# Altium schematic sheet Extra7_GPIO.SchDoc
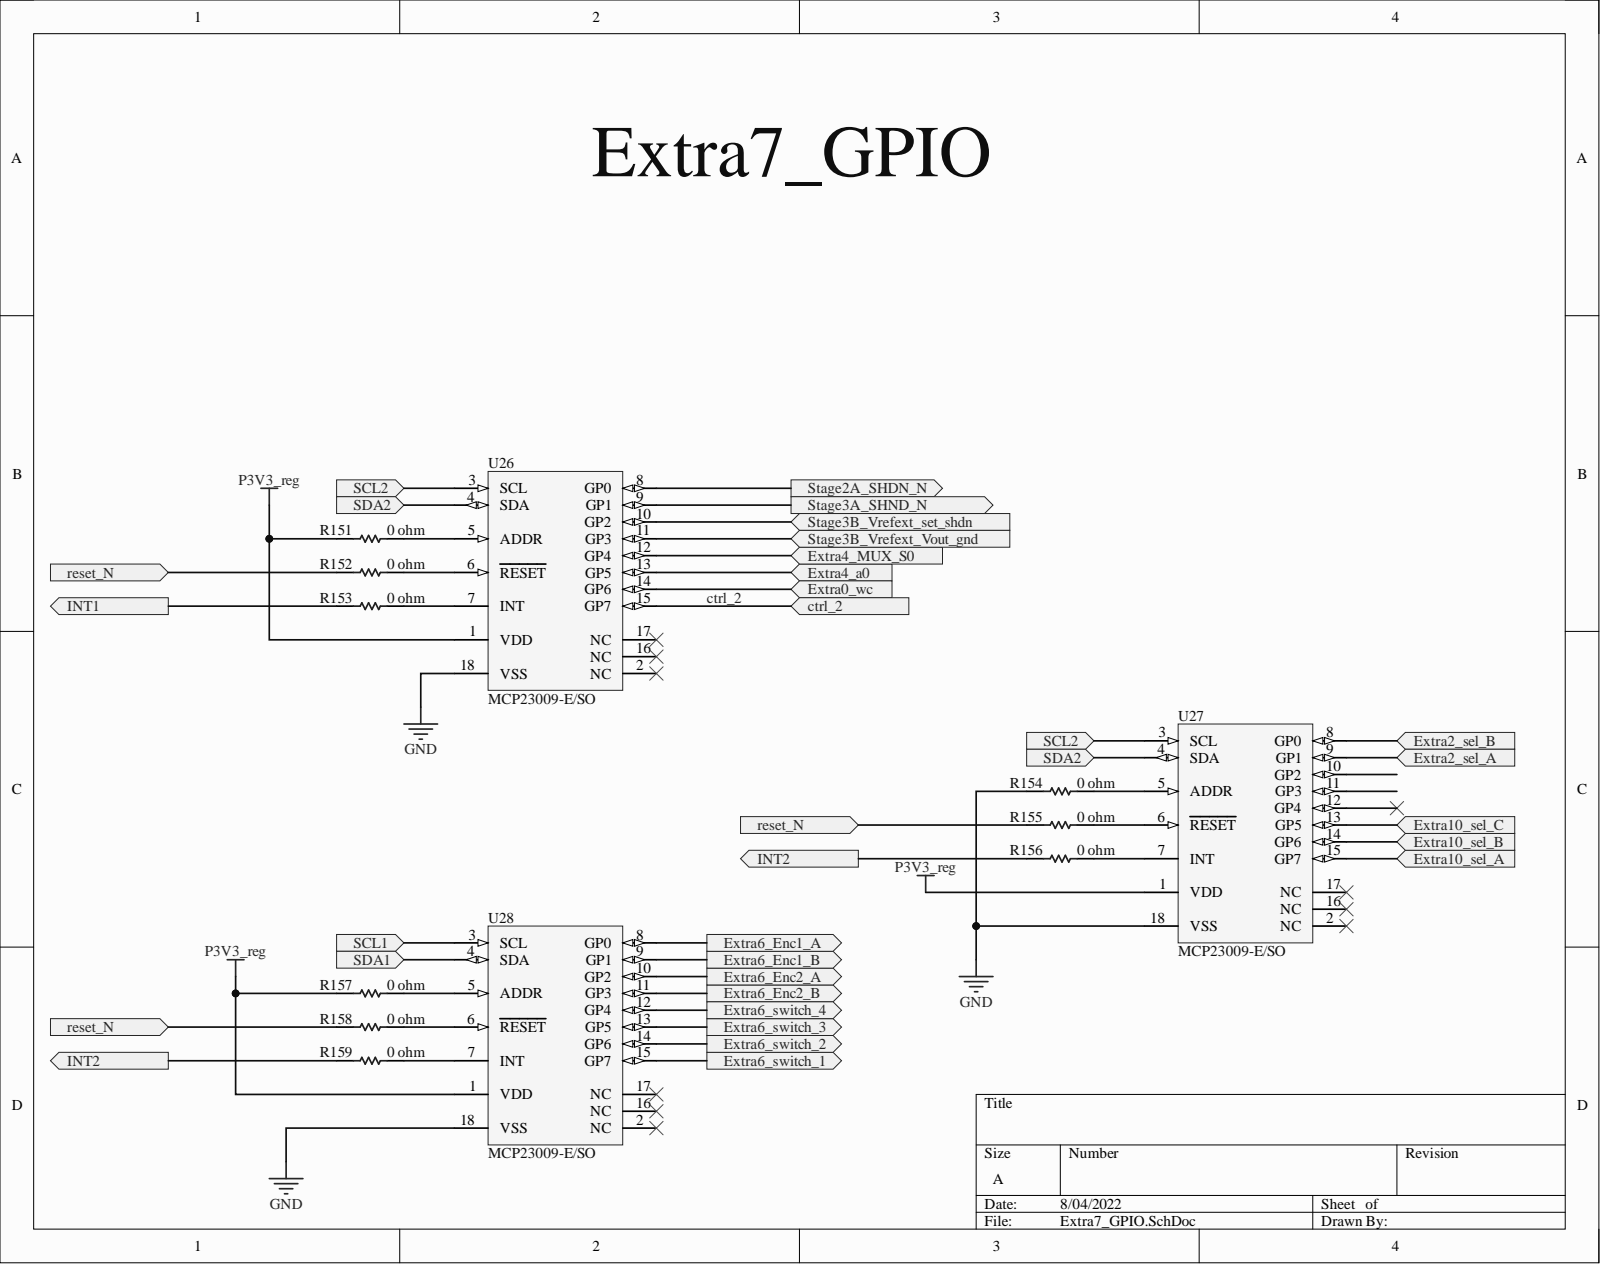
[diagram p0: Extra7_GPIO.SchDoc]

## Parts
R151  0 ohm  [RESC2013X50X30LL20T20]
R152  0 ohm  [RESC2013X50X30LL20T20]
R153  0 ohm  [RESC2013X50X30LL20T20]
R154  0 ohm  [RESC2013X50X30LL20T20]
R155  0 ohm  [RESC2013X50X30LL20T20]
R156  0 ohm  [RESC2013X50X30LL20T20]
R157  0 ohm  [RESC2013X50X30LL20T20]
R158  0 ohm  [RESC2013X50X30LL20T20]
R159  0 ohm  [RESC2013X50X30LL20T20]
U26  MCP23009-E/SO  [SOIC-SO18_L]
U27  MCP23009-E/SO  [SOIC-SO18_L]
U28  MCP23009-E/SO  [SOIC-SO18_L]

## Ports
ctrl_2, Extra0_wc, Extra2_sel_A, Extra2_sel_B, Extra4_a0, Extra4_MUX_S0, Extra6_Enc1_A, Extra6_Enc1_B, Extra6_Enc2_A, Extra6_Enc2_B, Extra6_switch_1, Extra6_switch_2, Extra6_switch_3, Extra6_switch_4, Extra10_sel_A, Extra10_sel_B, Extra10_sel_C, INT1, INT2, reset_N, SCL1, SCL2, SDA1, SDA2, Stage2A_SHDN_N, Stage3A_SHND_N, Stage3B_Vrefext_set_shdn, Stage3B_Vrefext_Vout_gnd

## Nets
GND: R154.1 U26.18(VSS) U27.18(VSS) U28.18(VSS)
P3V3_reg: R151.1 R157.1 U26.1(VDD) U27.1(VDD) U28.1(VDD)
ctrl_2: U26.15(GP7) ; port ctrl_2
Extra0_wc: U26.14(GP6) ; port Extra0_wc
Extra2_sel_A: U27.9(GP1) ; port Extra2_sel_A
Extra2_sel_B: U27.8(GP0) ; port Extra2_sel_B
Extra4_a0: U26.13(GP5) ; port Extra4_a0
Extra4_MUX_S0: U26.12(GP4) ; port Extra4_MUX_S0
Extra6_Enc1_A: U28.8(GP0) ; port Extra6_Enc1_A
Extra6_Enc1_B: U28.9(GP1) ; port Extra6_Enc1_B
Extra6_Enc2_A: U28.10(GP2) ; port Extra6_Enc2_A
Extra6_Enc2_B: U28.11(GP3) ; port Extra6_Enc2_B
Extra6_switch_1: U28.15(GP7) ; port Extra6_switch_1
Extra6_switch_2: U28.14(GP6) ; port Extra6_switch_2
Extra6_switch_3: U28.13(GP5) ; port Extra6_switch_3
Extra6_switch_4: U28.12(GP4) ; port Extra6_switch_4
Extra10_sel_A: U27.15(GP7) ; port Extra10_sel_A
Extra10_sel_B: U27.14(GP6) ; port Extra10_sel_B
Extra10_sel_C: U27.13(GP5) ; port Extra10_sel_C
INT1: R153.1 ; port INT1
INT2: R156.1 R159.1 ; port INT2
reset_N: R152.1 R155.1 R158.1 ; port reset_N
SCL1: U28.3(SCL) ; port SCL1
SCL2: U26.3(SCL) U27.3(SCL) ; port SCL2
SDA1: U28.4(SDA) ; port SDA1
SDA2: U26.4(SDA) U27.4(SDA) ; port SDA2
Stage2A_SHDN_N: U26.8(GP0) ; port Stage2A_SHDN_N
Stage3A_SHND_N: U26.9(GP1) ; port Stage3A_SHND_N
Stage3B_Vrefext_set_shdn: U26.10(GP2) ; port Stage3B_Vrefext_set_shdn
Stage3B_Vrefext_Vout_gnd: U26.11(GP3) ; port Stage3B_Vrefext_Vout_gnd
NetR151_2: R151.2 U26.5(ADDR)
NetR152_2: R152.2 U26.6(RESET)
NetR153_2: R153.2 U26.7(INT)
NetR154_2: R154.2 U27.5(ADDR)
NetR155_2: R155.2 U27.6(RESET)
NetR156_2: R156.2 U27.7(INT)
NetR157_2: R157.2 U28.5(ADDR)
NetR158_2: R158.2 U28.6(RESET)
NetR159_2: R159.2 U28.7(INT)

Not connected: U26 2(NC) 16(NC) 17(NC); U27 2(NC) 10(GP2) 11(GP3) 12(GP4) 16(NC) 17(NC); U28 2(NC) 16(NC) 17(NC)

## Library data of the parts
R151-R159 = CMP-1904075-2: RES SMD 0.0 OHM JUMPER 1/8W 0805; Manufacturer Part Number: CRCW08050000ZSTA; Case/Package (SI): 2012; Lead-Free Status: Contains Lead; Power Dissipation: 0.125 W; Resistance: 0.0 Ω; Resistance Tolerance: ±1%; Voltage Rating (DC): 150.0 V; Component Kind: Standard; Library Name: My Personal Space; Mounting Style: Surface Mount; Number of Pins: 2; Octopart Url: https://octopart.com/crcw08050000zsta-vishay-39388113; OctopartID: 3b91f0c21d26e456; Power Rating: 0.125 W; REACH SVHC Compliance: No SVHC; RoHS: Non-Compliant; Size-Height: 0.00045 m; Size-Length: 0.002 m; Size-Width: 0.00125 m
U26-U28 = CMP-1159035-3: 8-Bit I/O Expander with Open-Drain Outputs, High-Speed I2C Interface, 18-Pin SOIC, Extended Temperature; Lead-Free Status: Lead Free; Supply Current: 0.001 A; Case/Package: SOIC; Component Kind: Standard; Library Name: My Personal Space; Lifecycle Status: Active; Manufacturer Part Number: MCP23009-E/SO; Mounting Style: Surface Mount; Number of Pins: 18; Octopart Url: https://octopart.com/mcp23009-e%2Fso-microchip-9416504; OctopartID: 2073e9f6aa2dffb4; REACH SVHC Compliance: No SVHC; RoHS: Compliant
